# Altium schematic sheet AIL_TSAL_Schem.SchDoc(AIL_TSAL_Schem)
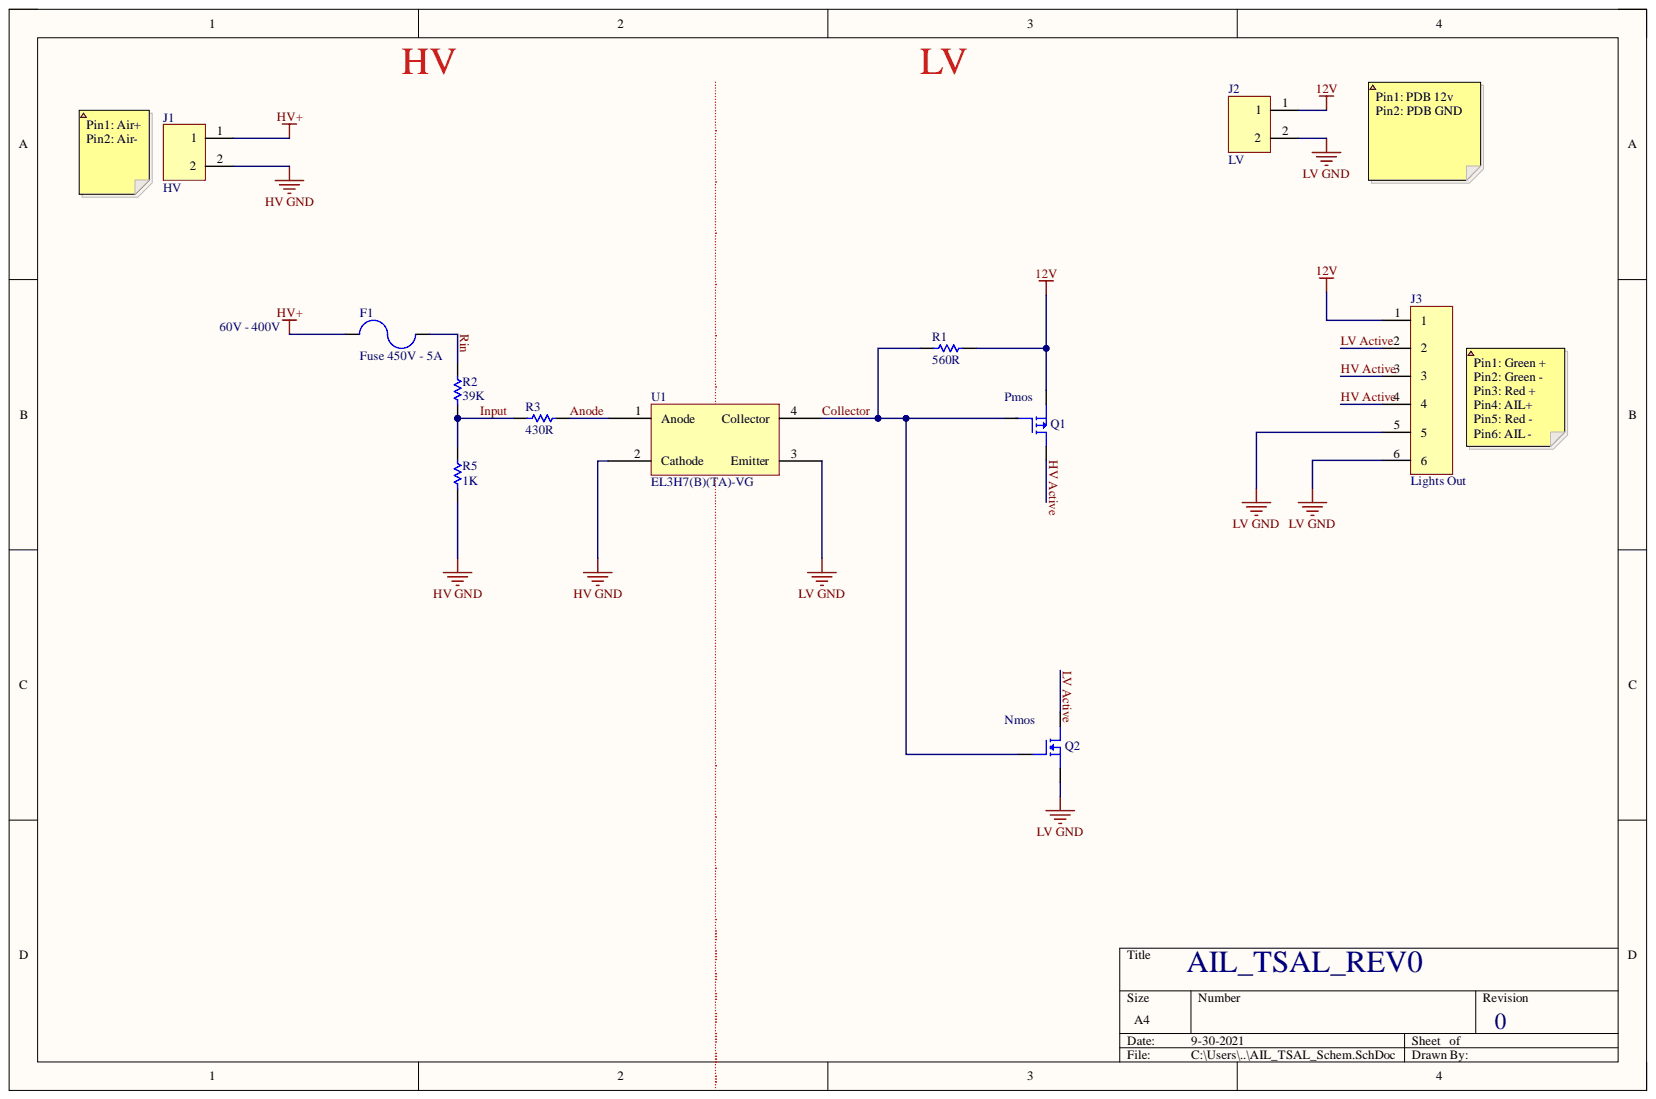
[diagram p0: AIL_TSAL_Schem.SchDoc]

## Parts
F1  Fuse 450V - 5A  [Fuse-11.2x5.05]
J1  HV  [2PIN]
J2  LV  [2PIN]
J3  Lights Out  [6PIN]
Q1  NTD2955T4GOSCT-ND  [DPAK-TO252]  (value not drawn)
Q2  IPD50N06S4L12ATMA2CT-ND  [DPAK-TO252]  (value not drawn)
R1  560R  [RES-0603]
R2  39K  [RES-0603]
R3  430R  [RES-0603]
R5  1K  [RES-0603]
U1  EL3H7(B)(TA)-VG  [SOIC127P700X200-4N]

## Nets
12V: J2.1 J3.1 Q1.3 R1.2
HV GND: J1.2 R5.1 U1.2(Cathode)
HV+: F1.1 J1.1
LV GND: J2.2 J3.5 J3.6 Q2.3 U1.3(Emitter)
Anode: R3.2 U1.1(Anode)
Collector: Q1.1 Q2.1 R1.1 U1.4(Collector)
HV Active: J3.3 J3.4 Q1.2
Input: R2.1 R3.1 R5.2
LV Active: J3.2 Q2.2
Rin: F1.2 R2.2

## Library data of the parts
F1 = Fuse 450V - 5A: FUSE 500VDC 350VAC SMT 5A; Component Kind: Standard; Library Name: Misc.SchLib; Supplier 1: DigiKey
J1 J2 = 2PIN: CONN HEADER R/A 2POS 2.54MM; Manufacturer: Molex; Manufacturer Part Number: 0705530106; Connector Type: Male Pin; Contact Resistance: 30mR; Number of Contacts: 2; Color: Black; Component Kind: Standard; ComponentLink1Description: http://www.molex.com/webdocs/datasheets/pdf/en-us/0705530106_PCB_HEADERS.pdf?src-supplier=Avnet+Asia; ComponentLink1URL: http://www.molex.com/webdocs/datasheets/pdf/en-us/0705530106_PCB_HEADERS.pdf?src-supplier=Avnet+Asia; ComponentLink2Description: https://www.molex.com/pdm_docs/ps/PS-70400.pdf?src-supplier=Digi-Key; ComponentLink2URL: https://www.molex.com/pdm_docs/ps/PS-70400.pdf?src-supplier=Digi-Key; Contact Material: Bronze; Contact Mating Length: 0.24inch; Contact Plating: Gold; Depth: 13.59mm; Housing Color: Black; Housing Material: Polyester; Length: 7.37mm; Library Name: Connectors.SchLib; Max Operating Temperature: 105°C; Min Operating Temperature: -40°C; Mount: Through Hole; Number of Positions: 2; Number of Rows: 1; Orientation: Right Angle; Packaging: Rail/Tube; Pitch: 2.54mm; Pricing 1: 1=1.38, 10=1.25, 100=1.071, 500=0.8925, 1000=0.765, 5000=0.6783, 10000=0.663 (USD); Stock 1: 2224; Supplier 1: Digi-Key; Supplier Part Number 1: WM4153-ND; Termination: Solder
J3 = 6PIN: CONN HEADER R/A 6POS 2.54MM; Manufacturer: Molex; Manufacturer Part Number: 70553-0040; Contact Resistance: 30mR; Current Rating: 3A; Gender: Male; Glow Wire Compliant: Non-compliant; Insulation Resistance: 1GR; Max Voltage Rating (AC): 250V; Number of Circuits: 6; Color: Black; Component Kind: Standard; ComponentLink1Description: http://uk.rs-online.com/webdocs/13c4/0900766b813c4993.pdf?src-supplier=RSComponents; ComponentLink1URL: http://uk.rs-online.com/webdocs/13c4/0900766b813c4993.pdf?src-supplier=RSComponents; ComponentLink2Description: http://www.molex.com/webdocs/datasheets/pdf/en-us/0705530040_PCB_HEADERS.pdf?src-supplier=Avnet+Europe; ComponentLink2URL: http://www.molex.com/webdocs/datasheets/pdf/en-us/0705530040_PCB_HEADERS.pdf?src-supplier=Avnet+Europe; ComponentLink3Description: http://www.farnell.com/datasheets/1844551.pdf?src-supplier=Farnell; ComponentLink3URL: http://www.farnell.com/datasheets/1844551.pdf?src-supplier=Farnell; ComponentLink4Description: http://www.mouser.com/ds/2/276/0705530040_PCB_HEADERS-172948.pdf?src-supplier=Mouser; ComponentLink4URL: http://www.mouser.com/ds/2/276/0705530040_PCB_HEADERS-172948.pdf?src-supplier=Mouser; ComponentLink5Description: http://www.farnell.com/cad/655744.pdf?src-supplier=Element14; ComponentLink5URL: http://www.farnell.com/cad/655744.pdf?src-supplier=Element14; ComponentLink6Description: http://www.jameco.com/Jameco/Products/ProdDS/1302975.pdf?src-supplier=Jameco; ComponentLink6URL: http://www.jameco.com/Jameco/Products/ProdDS/1302975.pdf?src-supplier=Jameco; Contact Material: Bronze,Copper Alloy,Brass; Contact Plating: Tin; Depth: 13.59mm; ELV: Compliant; Flammability Rating: UL94 V-0; Height: 6.99mm; Housing Color: Black; Housing Material: Thermoplastic; Lead Free: Lead Free; Length: 17.79mm; Library Name: Connectors.SchLib; Material: Brass; Max Current Rating: 3A; Max Operating Temperature: 105°C; Min Operating Temperature: -40°C; Mount: Through Hole; Number of Contacts: 6; Number of Positions: 6; Number of Rows: 1; Orientation: Right Angle; Package Quantity: 1200; Packaging: Rail/Tube; Pitch: 2.54mm; Pricing 1: 1=1.58, 10=1.434, 100=1.2289, 500=1.0241, 1000=0.8778, 5000=0.77832, 10000=0.76076 (USD); Radiation Hardening: No; REACH SVHC: Unknown; Stock 1: 2212; Supplier 1: Digi-Key; Supplier Part Number 1: WM4928-ND; Termination: Solder; Voltage Rating: 250V; Voltage Rating (DC): 250V
Q1 = P-FET-60V-12A: MOSFET P-CH 60V 12A DPAK; Manufacturer: ON Semiconductor; Current Rating: -12A; Drain to Source Breakdown Voltage: -60V; Drain to Source Resistance: 155mR; Element Configuration: Single; Fall Time: 48ns; Gate to Source Voltage (Vgs): 20V; Input Capacitance: 750pF; Max Power Dissipation: 55W; Nominal Vgs: -2.8V; Number of Channels: 1; On-State Resistance: 180mR; Rise Time: 45ns; Turn-Off Delay Time: 26ns; Turn-On Delay Time: 10ns; Case/Package: DPAK; Component Kind: Standard; ComponentLink1Description: http://www.onsemi.com/pub/Collateral/NTD2955-D.PDF?src-supplier=Avnet; ComponentLink1URL: http://www.onsemi.com/pub/Collateral/NTD2955-D.PDF?src-supplier=Avnet; ComponentLink2Description: http://www.onsemi.com/pub/Collateral/NTD2955-D.PDF?src-supplier=Avnet+Europe; ComponentLink2URL: http://www.onsemi.com/pub/Collateral/NTD2955-D.PDF?src-supplier=Avnet+Europe; ComponentLink3Description: http://www.onsemi.com/pub_link/Collateral/NTD2955-D.PDF?src-supplier=Element14; ComponentLink3URL: http://www.onsemi.com/pub_link/Collateral/NTD2955-D.PDF?src-supplier=Element14; ComponentLink4Description: http://www.onsemi.com/pub/Collateral/NTD2955-D.PDF?src-supplier=Digi-Key; ComponentLink4URL: http://www.onsemi.com/pub/Collateral/NTD2955-D.PDF?src-supplier=Digi-Key; ComponentLink5Description: http://www.jameco.com/Jameco/Products/ProdDS/1178118.pdf?src-supplier=Jameco; ComponentLink5URL: http://www.jameco.com/Jameco/Products/ProdDS/1178118.pdf?src-supplier=Jameco; Contact Plating: Tin,Matte; Continuous Drain Current (ID): 12A; Drain to Source Voltage (Vdss): -60V; Height: 2.38mm; Lead Free: Lead Free; Length: 6.73mm; Library Name: Transistors.SchLib; Manufacturer Part Number: NTD2955T4G; Max Operating Temperature: 175°C; Min Operating Temperature: -55°C; Number of Elements: 1; Number of Pins: 3; Package Quantity: 1; Packaging: Tape and Reel; Power Dissipation: 55W; Pricing 1: 1=0.76, 10=0.672, 25=0.6316, 100=0.4583, 250=0.44204, 500=0.38296, 1000=0.32592 (USD); Radiation Hardening: No; Rds On Max: 180mR; REACH SVHC: No SVHC; Stock 1: 9993; Supplier 1: Digi-Key; Supplier Part Number 1: NTD2955T4GOSDKR-ND; Threshold Voltage: -2.8V; Voltage Rating (DC): -60V; Width: 6.22mm
Q2 = N-FET-60V-50A: MOSFET N-CH 60V 50A TO252-3; Manufacturer: Infineon; Fall Time: 5ns; Gate to Source Voltage (Vgs): 16V; Input Capacitance: 2.89nF; Max Power Dissipation: 50W; Rds On Max: 12mR; Rise Time: 2ns; Turn-Off Delay Time: 25ns; Turn-On Delay Time: 6ns; Case/Package: TO-252-3; Component Kind: Standard; Continuous Drain Current (ID): 50A; Drain to Source Voltage (Vdss): 60V; Library Name: Transistors.SchLib; Manufacturer Part Number: IPD50N06S4L12ATMA2; Max Dual Supply Voltage: 60V; Max Operating Temperature: 175°C; Min Operating Temperature: -55°C; Mount: Surface Mount; Number of Pins: 3; Packaging: Tape and Reel; Pricing 1: 1=0.92, 10=0.806, 25=0.7572, 100=0.5497, 250=0.53012, 500=0.45928, 1000=0.39088 (USD); Stock 1: 3187; Supplier 1: Digi-Key; Supplier Part Number 1: IPD50N06S4L12ATMA2DKR-ND
R1 = 0603 - 560R: RES 560 OHM 1% 1/10W 0603; Component Kind: Standard; Library Name: Resistors.SchLib; Supplier 1: Digi-Key
R2 = 0603-39KR: RES SMD 39K OHM 1% 1/10W; Manufacturer: TE Connectivity; Manufacturer Part Number: CRGCQ0603F39K; Max Power Dissipation: 100mW; Resistance: 39kR; Temperature Coefficient: 100ppm/°C; Case Code (Imperial): 0603; Case Code (Metric): 1608; Case/Package: 0603; Component Kind: Standard; ComponentLink1Description: https://4donline.ihs.com/images/VipMasterIC/IC/TYEL/TYEL-S-A0004929575/TYEL-S-A0004929575-1.pdf?hkey=52A5661711E402568146F3353EA87419&src-supplier=Newark; ComponentLink1URL: https://4donline.ihs.com/images/VipMasterIC/IC/TYEL/TYEL-S-A0004929575/TYEL-S-A0004929575-1.pdf?hkey=52A5661711E402568146F3353EA87419&src-supplier=Newark; ComponentLink2Description: https://4donline.ihs.com/images/VipMasterIC/IC/TYEL/TYEL-S-A0004929575/TYEL-S-A0004929575-1.pdf?hkey=52A5661711E402568146F3353EA87419&src-supplier=Farnell; ComponentLink2URL: https://4donline.ihs.com/images/VipMasterIC/IC/TYEL/TYEL-S-A0004929575/TYEL-S-A0004929575-1.pdf?hkey=52A5661711E402568146F3353EA87419&src-supplier=Farnell; ComponentLink3Description: https://www.te.com/commerce/DocumentDelivery/DDEController?Action=srchrtrv&DocNm=1773204-3&DocType=DS&DocLang=English&src-supplier=Digi-Key; ComponentLink3URL: https://www.te.com/commerce/DocumentDelivery/DDEController?Action=srchrtrv&DocNm=1773204-3&DocType=DS&DocLang=English&src-supplier=Digi-Key; ComponentLink4Description: https://www.te.com/commerce/DocumentDelivery/DDEController?Action=srchrtrv&amp;DocNm=1773204-3&amp;DocType=DS&amp;DocLang=English&src-supplier=Digi-Key; ComponentLink4URL: https://www.te.com/commerce/DocumentDelivery/DDEController?Action=srchrtrv&amp;DocNm=1773204-3&amp;DocType=DS&amp;DocLang=English&src-supplier=Digi-Key; Composition: Thick Film; Features: Moisture Resistant,Automotive AEC-Q200; Library Name: Resistors.SchLib; Max Operating Temperature: 155°C; Min Operating Temperature: -55°C; Number of Terminations: 2; Packaging: Tape and Reel; Pricing 1: 1=0.1, 10=0.03, 100=0.012, 1000=0.00541, 2500=0.00469 (USD); Stock 1: 57588; Supplier 1: Digi-Key; Supplier Part Number 1: A129707DKR-ND; Tolerance: 1%
R3 = 0603 - 430R: RES 430 OHM 1% 1/10W 0603; Component Kind: Standard; Library Name: Resistors.SchLib; Supplier 1: Digi-Key
R5 = 0603-1KR: RES SMD 1K OHM 1% 1/10W 0603; Manufacturer: Vishay Dale; Manufacturer Part Number: CRCW06031K00FKEA; Max Power Dissipation: 100mW; Resistance: 1kR; Temperature Coefficient: 100ppm/°C; Voltage Rating: 75V; Case Code (Imperial): 0603; Case Code (Metric): 1608; Case/Package: 0603; Component Kind: Standard; ComponentLink1Description: http://www.vishay.com/docs/20035/dcrcwe3.pdf?src-supplier=Digi-Key; ComponentLink1URL: http://www.vishay.com/docs/20035/dcrcwe3.pdf?src-supplier=Digi-Key; ComponentLink2Description: http://uk.rs-online.com/webdocs/0d98/0900766b80d98afc.pdf?src-supplier=RSComponents; ComponentLink2URL: http://uk.rs-online.com/webdocs/0d98/0900766b80d98afc.pdf?src-supplier=RSComponents; ComponentLink3Description: http://www.vishay.com/docs/20035/dcrcwe3.pdf?src-supplier=Avnet; ComponentLink3URL: http://www.vishay.com/docs/20035/dcrcwe3.pdf?src-supplier=Avnet; ComponentLink4Description: http://www.vishay.com/docs/20035/dcrcwe3.pdf?src-supplier=Avnet+Asia; ComponentLink4URL: http://www.vishay.com/docs/20035/dcrcwe3.pdf?src-supplier=Avnet+Asia; ComponentLink5Description: http://www.farnell.com/datasheets/2310790.pdf?src-supplier=Element14; ComponentLink5URL: http://www.farnell.com/datasheets/2310790.pdf?src-supplier=Element14; ComponentLink6Description: https://www.distrelec.biz/Web/Downloads/a_/en/flD-CRCW-e3_data_en.pdf?mime=application%2Fpdf&src-supplier=Distrelec; ComponentLink6URL: https://www.distrelec.biz/Web/Downloads/a_/en/flD-CRCW-e3_data_en.pdf?mime=application%2Fpdf&src-supplier=Distrelec; ComponentLink7Description: http://www.vishay.com/docs/20035/dcrcwe3.pdf?src-supplier=Future+Electronics; ComponentLink7URL: http://www.vishay.com/docs/20035/dcrcwe3.pdf?src-supplier=Future+Electronics; ComponentLink8Description: http://www.vishay.com/docs/20035/dcrcwe3.pdf?src-supplier=Avnet+Europe; ComponentLink8URL: http://www.vishay.com/docs/20035/dcrcwe3.pdf?src-supplier=Avnet+Europe; Composition: Thick Film; Contact Plating: Tin; Depth: 0.85mm; Features: Automotive AEC-Q200; Height: 0.45mm; Lead Free: Lead Free; Length: 1.55mm; Library Name: Resistors.SchLib; Max Operating Temperature: 155°C; Military Standard: Not; Min Operating Temperature: -55°C; Mount: Surface Mount; Number of Pins: 2; Number of Terminations: 2; Package Quantity: 10; Packaging: Tape and Reel; Power Rating: 100mW; Pricing 1: 1=0.1, 10=0.034, 100=0.0139, 1000=0.00623, 2500=0.0054 (USD); Radiation Hardening: No; REACH SVHC: Unknown; Stock 1: 671453; Supplier 1: Digi-Key; Supplier Part Number 1: 541-1.00KHCT-ND; Termination: SMD/SMT; Tolerance: 1%; Voltage Rating (DC): 75V; Weight: 7.1E-05oz; Width: 0.033inch
U1 = EL3H7(B)(TA)-VG: OPTOISOLATOR 3.75KV TRANS 4-SSOP; Manufacturer: Everlight; Approvals: UL; Collector Emitter Breakdown Voltage: 80V; Collector Emitter Saturation Voltage: 200mV; Current Transfer Ratio: 260%; Fall Time: 18000ns; Forward Current: 50mA; Forward Voltage: 1.4V; Max Power Dissipation: 200mW; Number of Channels: 1; Reverse Breakdown Voltage: 6V; Case/Package: SSOP; Collector Emitter Voltage (VCEO): 80V; Component Kind: Standard; ComponentLink1Description: https://everlightamericas.com/index.php?controller=attachment&id_attachment=2584&src-supplier=Digi-Key; ComponentLink1URL: https://everlightamericas.com/index.php?controller=attachment&id_attachment=2584&src-supplier=Digi-Key; ComponentLink2Description: https://everlightamericas.com/index.php?controller=attachment&amp;id_attachment=2584&src-supplier=Digi-Key; ComponentLink2URL: https://everlightamericas.com/index.php?controller=attachment&amp;id_attachment=2584&src-supplier=Digi-Key; ComponentLink3Description: https://www.verical.com/server-webapp/html/getDatasheet?partID=416716&src-supplier=Verical; ComponentLink3URL: https://www.verical.com/server-webapp/html/getDatasheet?partID=416716&src-supplier=Verical; Isolation Voltage: 3.75kV; Lead Free: Lead Free; Manufacturer Part Number: EL3H7(B)(TA)-VG; Max Collector Current: 50mA; Max Input Current: 50mA; Max Operating Temperature: 125°C; Max Output Voltage: 80V; Min Operating Temperature: -55°C; Mount: Surface Mount; Number of Elements: 1; Number of Pins: 4; Output Current per Channel: 50mA; Package Quantity: 1; Packaging: Tape and Reel; Power Dissipation: 200mW; Pricing 1: 1=0.42, 10=0.304, 25=0.248, 100=0.1985, 250=0.16752, 500=0.1427, 1000=0.11167, 2500=0.10237 (USD); Radiation Hardening: No; Rise Time: 18000ns; Stock 1: 119652; Supplier 1: Digi-Key; Supplier Part Number 1: 1080-1197-6-ND; Library Name: Schlib1_TSAL.SchLib
